# Altium schematic sheet LED Matrix-2.SchDoc("LED Matrix-2")
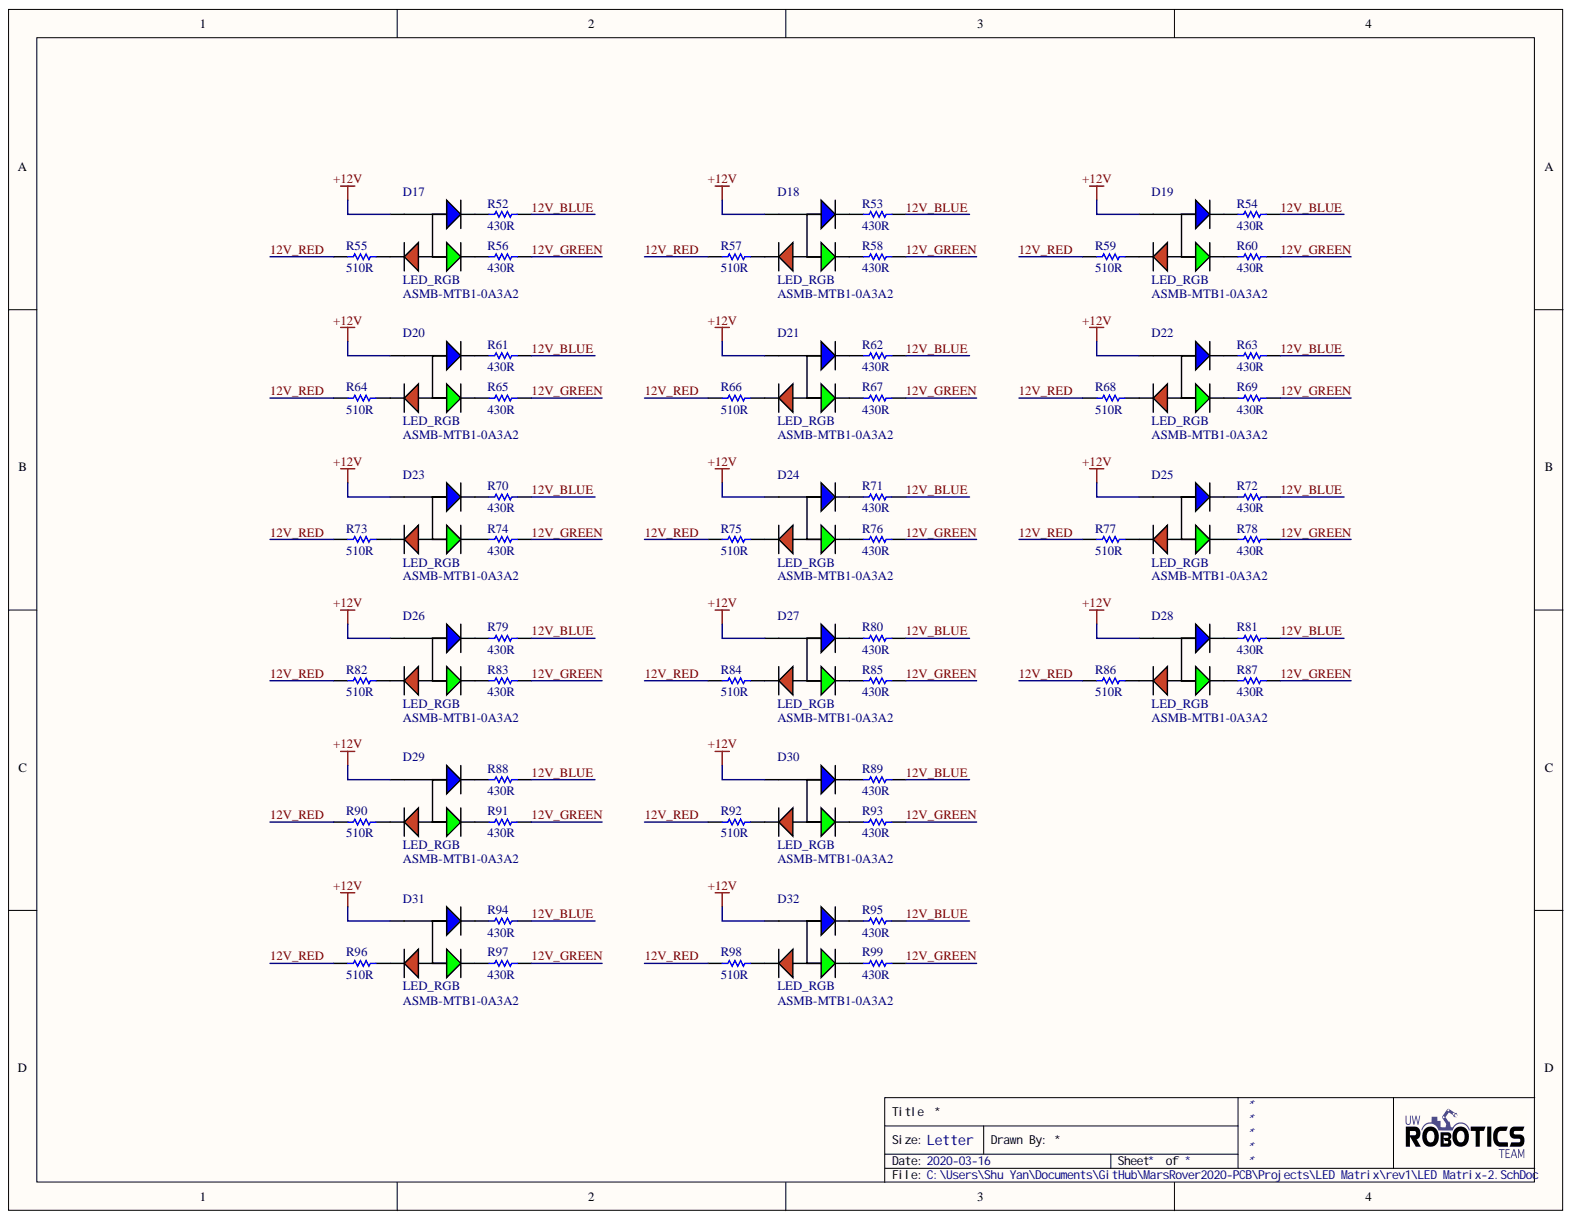
[diagram p0: LED Matrix-2.SchDoc]

## Parts
D17  LED_RGB  Manufacturer Part Number 1: ASMB-MTB1-0A3A2  [LED_RGB]
D18  LED_RGB  Manufacturer Part Number 1: ASMB-MTB1-0A3A2  [LED_RGB]
D19  LED_RGB  Manufacturer Part Number 1: ASMB-MTB1-0A3A2  [LED_RGB]
D20  LED_RGB  Manufacturer Part Number 1: ASMB-MTB1-0A3A2  [LED_RGB]
D21  LED_RGB  Manufacturer Part Number 1: ASMB-MTB1-0A3A2  [LED_RGB]
D22  LED_RGB  Manufacturer Part Number 1: ASMB-MTB1-0A3A2  [LED_RGB]
D23  LED_RGB  Manufacturer Part Number 1: ASMB-MTB1-0A3A2  [LED_RGB]
D24  LED_RGB  Manufacturer Part Number 1: ASMB-MTB1-0A3A2  [LED_RGB]
D25  LED_RGB  Manufacturer Part Number 1: ASMB-MTB1-0A3A2  [LED_RGB]
D26  LED_RGB  Manufacturer Part Number 1: ASMB-MTB1-0A3A2  [LED_RGB]
D27  LED_RGB  Manufacturer Part Number 1: ASMB-MTB1-0A3A2  [LED_RGB]
D28  LED_RGB  Manufacturer Part Number 1: ASMB-MTB1-0A3A2  [LED_RGB]
D29  LED_RGB  Manufacturer Part Number 1: ASMB-MTB1-0A3A2  [LED_RGB]
D30  LED_RGB  Manufacturer Part Number 1: ASMB-MTB1-0A3A2  [LED_RGB]
D31  LED_RGB  Manufacturer Part Number 1: ASMB-MTB1-0A3A2  [LED_RGB]
D32  LED_RGB  Manufacturer Part Number 1: ASMB-MTB1-0A3A2  [LED_RGB]
R52  value 430R  [RESC2012X60N]  (hidden comment: RES_430R_0805)
R53  value 430R  [RESC2012X60N]  (hidden comment: RES_430R_0805)
R54  value 430R  [RESC2012X60N]  (hidden comment: RES_430R_0805)
R55  value 510R  [RESC2012X60N]  (hidden comment: RES_510R_0805)
R56  value 430R  [RESC2012X60N]  (hidden comment: RES_430R_0805)
R57  value 510R  [RESC2012X60N]  (hidden comment: RES_510R_0805)
R58  value 430R  [RESC2012X60N]  (hidden comment: RES_430R_0805)
R59  value 510R  [RESC2012X60N]  (hidden comment: RES_510R_0805)
R60  value 430R  [RESC2012X60N]  (hidden comment: RES_430R_0805)
R61  value 430R  [RESC2012X60N]  (hidden comment: RES_430R_0805)
R62  value 430R  [RESC2012X60N]  (hidden comment: RES_430R_0805)
R63  value 430R  [RESC2012X60N]  (hidden comment: RES_430R_0805)
R64  value 510R  [RESC2012X60N]  (hidden comment: RES_510R_0805)
R65  value 430R  [RESC2012X60N]  (hidden comment: RES_430R_0805)
R66  value 510R  [RESC2012X60N]  (hidden comment: RES_510R_0805)
R67  value 430R  [RESC2012X60N]  (hidden comment: RES_430R_0805)
R68  value 510R  [RESC2012X60N]  (hidden comment: RES_510R_0805)
R69  value 430R  [RESC2012X60N]  (hidden comment: RES_430R_0805)
R70  value 430R  [RESC2012X60N]  (hidden comment: RES_430R_0805)
R71  value 430R  [RESC2012X60N]  (hidden comment: RES_430R_0805)
R72  value 430R  [RESC2012X60N]  (hidden comment: RES_430R_0805)
R73  value 510R  [RESC2012X60N]  (hidden comment: RES_510R_0805)
R74  value 430R  [RESC2012X60N]  (hidden comment: RES_430R_0805)
R75  value 510R  [RESC2012X60N]  (hidden comment: RES_510R_0805)
R76  value 430R  [RESC2012X60N]  (hidden comment: RES_430R_0805)
R77  value 510R  [RESC2012X60N]  (hidden comment: RES_510R_0805)
R78  value 430R  [RESC2012X60N]  (hidden comment: RES_430R_0805)
R79  value 430R  [RESC2012X60N]  (hidden comment: RES_430R_0805)
R80  value 430R  [RESC2012X60N]  (hidden comment: RES_430R_0805)
R81  value 430R  [RESC2012X60N]  (hidden comment: RES_430R_0805)
R82  value 510R  [RESC2012X60N]  (hidden comment: RES_510R_0805)
R83  value 430R  [RESC2012X60N]  (hidden comment: RES_430R_0805)
R84  value 510R  [RESC2012X60N]  (hidden comment: RES_510R_0805)
R85  value 430R  [RESC2012X60N]  (hidden comment: RES_430R_0805)
R86  value 510R  [RESC2012X60N]  (hidden comment: RES_510R_0805)
R87  value 430R  [RESC2012X60N]  (hidden comment: RES_430R_0805)
R88  value 430R  [RESC2012X60N]  (hidden comment: RES_430R_0805)
R89  value 430R  [RESC2012X60N]  (hidden comment: RES_430R_0805)
R90  value 510R  [RESC2012X60N]  (hidden comment: RES_510R_0805)
R91  value 430R  [RESC2012X60N]  (hidden comment: RES_430R_0805)
R92  value 510R  [RESC2012X60N]  (hidden comment: RES_510R_0805)
R93  value 430R  [RESC2012X60N]  (hidden comment: RES_430R_0805)
R94  value 430R  [RESC2012X60N]  (hidden comment: RES_430R_0805)
R95  value 430R  [RESC2012X60N]  (hidden comment: RES_430R_0805)
R96  value 510R  [RESC2012X60N]  (hidden comment: RES_510R_0805)
R97  value 430R  [RESC2012X60N]  (hidden comment: RES_430R_0805)
R98  value 510R  [RESC2012X60N]  (hidden comment: RES_510R_0805)
R99  value 430R  [RESC2012X60N]  (hidden comment: RES_430R_0805)

## Nets
+12V: D17.4 D18.4 D19.4 D20.4 D21.4 D22.4 D23.4 D24.4 D25.4 D26.4 D27.4 D28.4 D29.4 D30.4 D31.4 D32.4 R52.1 R53.1 R54.1 R55.2 R56.1 R57.2 R58.1 R59.2 R60.1 R61.1 R62.1 R63.1 R64.2 R65.1 R66.2 R67.1 R68.2 R69.1 R70.1 R71.1 R72.1 R73.2 R74.1 R75.2 R76.1 R77.2 R78.1 R79.1 R80.1 R81.1 R82.2 R83.1 R84.2 R85.1 R86.2 R87.1 R88.1 R89.1 R90.2 R91.1 R92.2 R93.1 R94.1 R95.1 R96.2 R97.1 R98.2 R99.1  [also labelled 12V_BLUE, 12V_GREEN, 12V_RED]
NetD17_1: D17.1 R55.1
NetD17_2: D17.2 R56.2
NetD17_3: D17.3 R52.2
NetD18_1: D18.1 R57.1
NetD18_2: D18.2 R58.2
NetD18_3: D18.3 R53.2
NetD19_1: D19.1 R59.1
NetD19_2: D19.2 R60.2
NetD19_3: D19.3 R54.2
NetD20_1: D20.1 R64.1
NetD20_2: D20.2 R65.2
NetD20_3: D20.3 R61.2
NetD21_1: D21.1 R66.1
NetD21_2: D21.2 R67.2
NetD21_3: D21.3 R62.2
NetD22_1: D22.1 R68.1
NetD22_2: D22.2 R69.2
NetD22_3: D22.3 R63.2
NetD23_1: D23.1 R73.1
NetD23_2: D23.2 R74.2
NetD23_3: D23.3 R70.2
NetD24_1: D24.1 R75.1
NetD24_2: D24.2 R76.2
NetD24_3: D24.3 R71.2
NetD25_1: D25.1 R77.1
NetD25_2: D25.2 R78.2
NetD25_3: D25.3 R72.2
NetD26_1: D26.1 R82.1
NetD26_2: D26.2 R83.2
NetD26_3: D26.3 R79.2
NetD27_1: D27.1 R84.1
NetD27_2: D27.2 R85.2
NetD27_3: D27.3 R80.2
NetD28_1: D28.1 R86.1
NetD28_2: D28.2 R87.2
NetD28_3: D28.3 R81.2
NetD29_1: D29.1 R90.1
NetD29_2: D29.2 R91.2
NetD29_3: D29.3 R88.2
NetD30_1: D30.1 R92.1
NetD30_2: D30.2 R93.2
NetD30_3: D30.3 R89.2
NetD31_1: D31.1 R96.1
NetD31_2: D31.2 R97.2
NetD31_3: D31.3 R94.2
NetD32_1: D32.1 R98.1
NetD32_2: D32.2 R99.2
NetD32_3: D32.3 R95.2

## Library data of the parts
D17-D32 = LED_RGB: LED RGB DIFFUSED 4PLCC SMD; Manufacturer 1: Broadcom; Viewing Angle: 115°; Test Current: 20mA; Luminous Intensity: 350mcd; Lens Style: Round; Lens Color: White; Forward Voltage: 3.1V; Dominant Wavelength: 470nm; Color: Blue,Green,Red; Width: 2.8mm; Supplier Part Number 1: 516-3280-1-ND; Supplier 1: Digi-Key; Stock 1: 142531; RoHS: Yes; REACH SVHC: No SVHC; Pricing 1: 1=0.60000, 10=0.47100, 100=0.30210, 500=0.25980, 1000=0.20059 (USD); Packaging: Cut Tape; Number of Pins: 4; Mount: Surface Mount; Lens Transparency: Diffused; Length: 3.2mm; Height: 1.9mm; ComponentLink4URL: http://www.farnell.com/datasheets/2111106.pdf?src-supplier=Newark; ComponentLink4Description: http://www.farnell.com/datasheets/2111106.pdf?src-supplier=Newark; ComponentLink3URL: http://www.farnell.com/datasheets/1801564.pdf?src-supplier=Element14; ComponentLink3Description: http://www.farnell.com/datasheets/1801564.pdf?src-supplier=Element14; ComponentLink2URL: https://docs.broadcom.com/docs/AV02-4194EN?src-supplier=Digi-Key; ComponentLink2Description: https://docs.broadcom.com/docs/AV02-4194EN?src-supplier=Digi-Key; ComponentLink1URL: http://www.farnell.com/datasheets/2111106.pdf?src-supplier=Farnell; ComponentLink1Description: http://www.farnell.com/datasheets/2111106.pdf?src-supplier=Farnell; Component Kind: Standard; Case/Package: PLCC; Library Name: UWRT_Schematic_Library_2020.SchLib
R52-R54 R56 R58 R60-R63 R65 R67 R69-R72 R74 R76 R78-R81 R83 R85 R87-R89 R91 R93-R95 R97 R99 = RES_430R_0805: RES SMD 430 OHM 1% 1/8W 0805; Manufacturer Part Number 1: CRCW0805430RFKEA; Manufacturer 1: Vishay Dale; Voltage Rating: 150V; Temperature Coefficient: 100ppm/°C; Power Rating: 125mW; Tolerance: 1%; Termination: SMD/SMT; Supplier Part Number 1: 541-430CCT-ND; Supplier 1: Digi-Key; Stock 1: 2755291; RoHS: Yes; Resistance: 430R; REACH SVHC: Unknown; Radiation Hardening: No; Pricing 1: 1=0.10000, 10=0.03500, 100=0.01400, 1000=0.00631, 2500=0.00548 (USD); Packaging: Tape and Reel; Mount: Surface Mount; Min Operating Temperature: -55°C; Military Standard: Not; Max Operating Temperature: 155°C; Length: 2mm; Lead Free: Lead Free; Depth: 1.25mm; Contact Plating: Tin,Lead,Matte; Composition: Thick Film; ComponentLink1URL: http://www.vishay.com/docs/20035/dcrcwe3.pdf; ComponentLink1Description: Datasheet; Component Kind: Standard; Case Code (Metric): 2012; Case Code (Imperial): 0805; Case/Package: Molded; Library Name: UWRT_Schematic_Library_2020.SchLib
R55 R57 R59 R64 R66 R68 R73 R75 R77 R82 R84 R86 R90 R92 R96 R98 = RES_510R_0805: RES SMD 510 OHM 1% 1/8W 0805; Manufacturer Part Number 1: CRCW0805510RFKEA; Manufacturer 1: Vishay Dale; Voltage Rating: 150V; Temperature Coefficient: 100ppm/°C; Power Rating: 125mW; Tolerance: 1%; Termination: SMD/SMT; Supplier Part Number 1: 541-510CCT-ND; Supplier 1: Digi-Key; Stock 1: 2755291; RoHS: Yes; Resistance: 510R; REACH SVHC: Unknown; Radiation Hardening: No; Pricing 1: 1=0.10000, 10=0.03500, 100=0.01400, 1000=0.00631, 2500=0.00548 (USD); Packaging: Tape and Reel; Mount: Surface Mount; Min Operating Temperature: -55°C; Military Standard: Not; Max Operating Temperature: 155°C; Length: 2mm; Lead Free: Lead Free; Depth: 1.25mm; Contact Plating: Tin,Lead,Matte; Composition: Thick Film; ComponentLink1URL: http://www.vishay.com/docs/20035/dcrcwe3.pdf; ComponentLink1Description: Datasheet; Component Kind: Standard; Case Code (Metric): 2012; Case Code (Imperial): 0805; Case/Package: Molded; Library Name: UWRT_Schematic_Library_2020.SchLib
